# Altium schematic sheet 02_HIERARCHICAL_BLOCKS.SchDoc
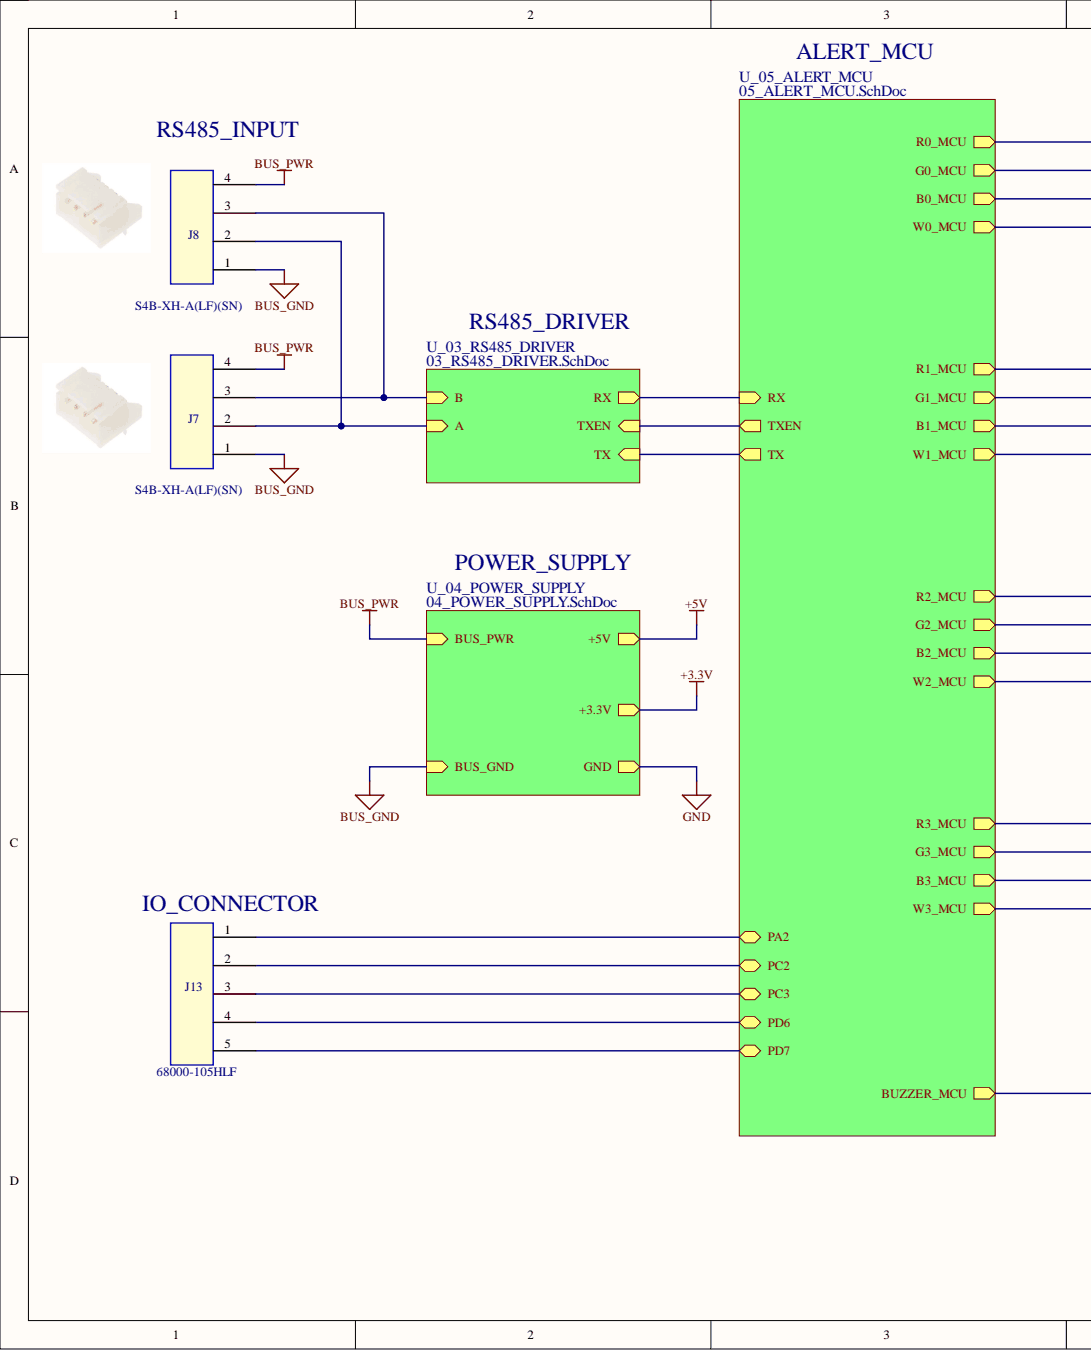
[diagram p0: 02_HIERARCHICAL_BLOCKS.SchDoc, left]
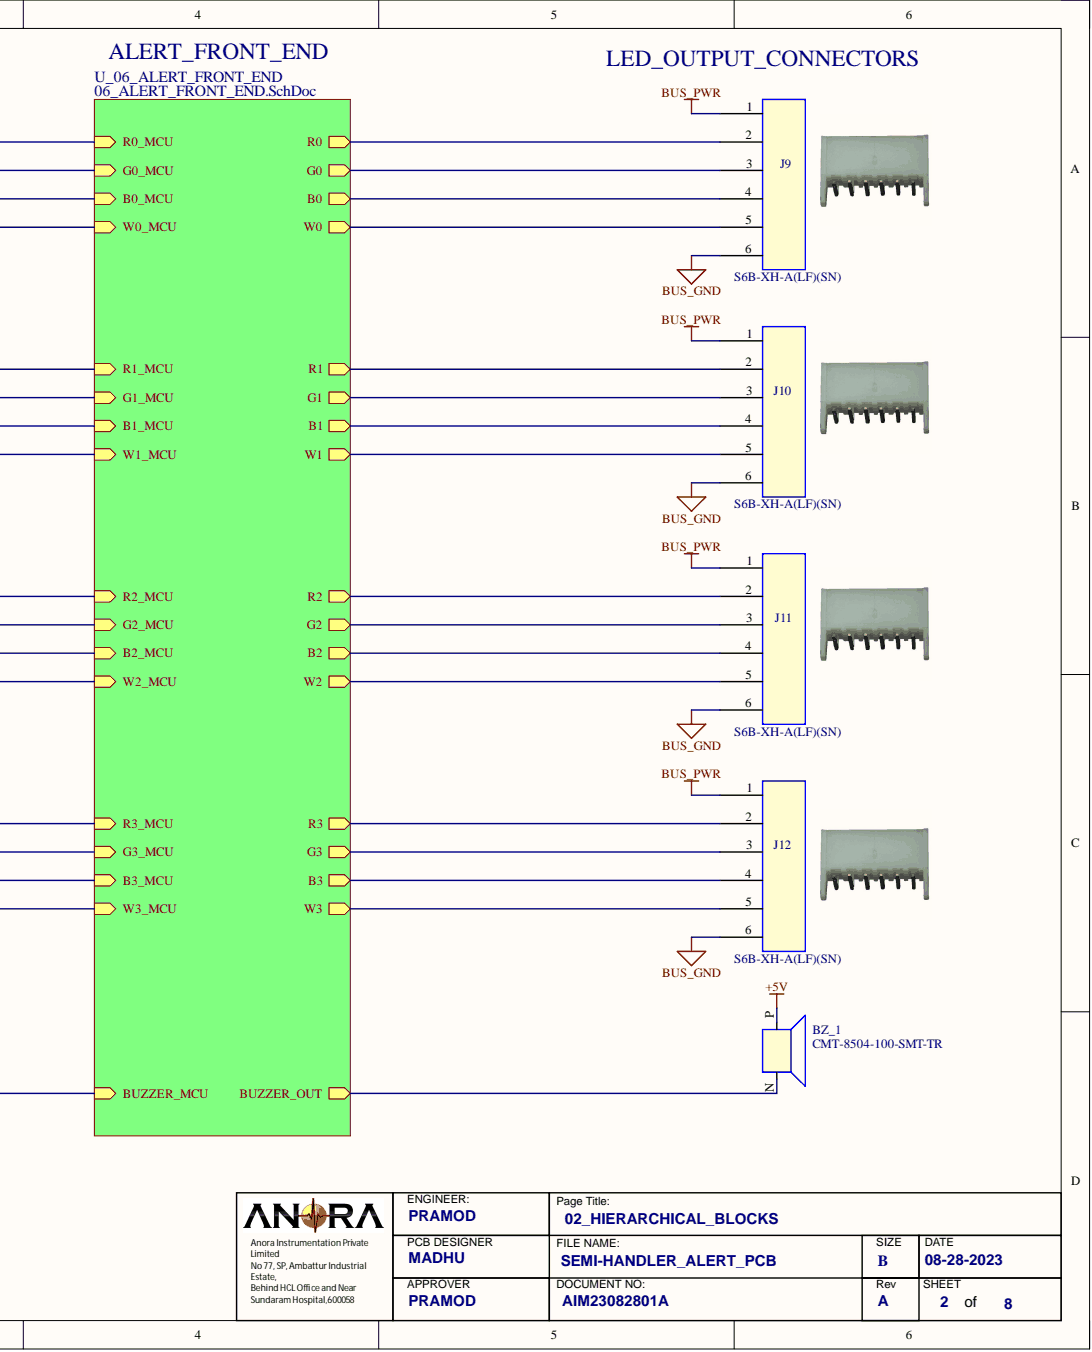
[diagram p1: 02_HIERARCHICAL_BLOCKS.SchDoc, right]

## Parts
BZ_1  CMT-8504-100-SMT-TR  [CMT-8504-100-SMT-TR]
J7  S4B-XH-A(LF)(SN)  [JST_S4B-XH-A]
J8  S4B-XH-A(LF)(SN)  [JST_S4B-XH-A]
J9  S6B-XH-A(LF)(SN)  [S6B-XH-A(LF)(SN)]
J10  S6B-XH-A(LF)(SN)  [S6B-XH-A(LF)(SN)]
J11  S6B-XH-A(LF)(SN)  [S6B-XH-A(LF)(SN)]
J12  S6B-XH-A(LF)(SN)  [S6B-XH-A(LF)(SN)]
J13  68000-105HLF  [BERG_STICK_1X5]

## Nets
+5V: BZ_1.P
BUS_GND: J7.1 J8.1 J9.6 J10.6 J11.6 J12.6
BUS_PWR: J7.4 J8.4 J9.1 J10.1 J11.1 J12.1
NetBZ_1_N: BZ_1.N
NetJ7_2: J7.2 J8.2
NetJ7_3: J7.3 J8.3
NetJ9_2: J9.2
NetJ9_3: J9.3
NetJ9_4: J9.4
NetJ9_5: J9.5
NetJ10_2: J10.2
NetJ10_3: J10.3
NetJ10_4: J10.4
NetJ10_5: J10.5
NetJ11_2: J11.2
NetJ11_3: J11.3
NetJ11_4: J11.4
NetJ11_5: J11.5
NetJ12_2: J12.2
NetJ12_3: J12.3
NetJ12_4: J12.4
NetJ12_5: J12.5
NetJ13_1: J13.1
NetJ13_2: J13.2
NetJ13_3: J13.3
NetJ13_4: J13.4
NetJ13_5: J13.5
+3.3V:
B0_MCU:
B1_MCU:
B2_MCU:
B3_MCU:
BUZZER_MCU:
G0_MCU:
G1_MCU:
G2_MCU:
G3_MCU:
GND:
R0_MCU:
R1_MCU:
R2_MCU:
R3_MCU:
RX:
TX:
TXEN:
W0_MCU:
W1_MCU:
W2_MCU:
W3_MCU:

## Library data of the parts
BZ_1 = CMT-8504-100-SMT-TR: BUZZER MAGNETIC 5V 8.5X8.5MM SMD; ASSY_OPT: YES; DNI: NO; MFG_NAME01: CUI Devices; Component Kind: Standard; MFG_PN01: CMT-8504-100-SMT-TR; ROHS: YES; VALUE: CMT-8504-100-SMT-TR; Library Name: SEMI-HANDLER_ALERT_PCB.SCHLIB
J7 J8 = S4B-XH-A(LF)(SN): CONN HEADER R/A 4POS 2.5MM; ASSY_OPT: YES; DNI: NO; MFG_NAME01: JST Sales America Inc.; Component Kind: Standard; MFG_PN01: S4B-XH-A(LF)(SN); ROHS: YES; VALUE: S4B-XH-A(LF)(SN); Library Name: MOTOR_CONTROL_RTU.SCHLIB
J9-J12 = S6B-XH-A(LF)(SN): CONN HEADER R/A 6POS 2.5MM; ASSY_OPT: YES; DNI: NO; MFG_NAME01: JST Sales America Inc.; Component Kind: Standard; MFG_PN01: S6B-XH-A(LF)(SN); ROHS: YES; VALUE: S6B-XH-A(LF)(SN); Library Name: SEMI-HANDLER_ALERT_PCB.SCHLIB
J13 = 68000-105HLF: Connector Header Through Hole 5 position 0.100" (2.54mm); ASSY_OPT: YES; DNI: NO; MFG_NAME01: Amphenol ICC (FCI); Component Kind: Standard; MFG_PN01: 68000-105HLF; ROHS: YES; VALUE: 68000-105HLF; Library Name: SEMI-HANDLER_ALERT_PCB.SCHLIB
